# Altium schematic sheet keen3_filet.SchDoc
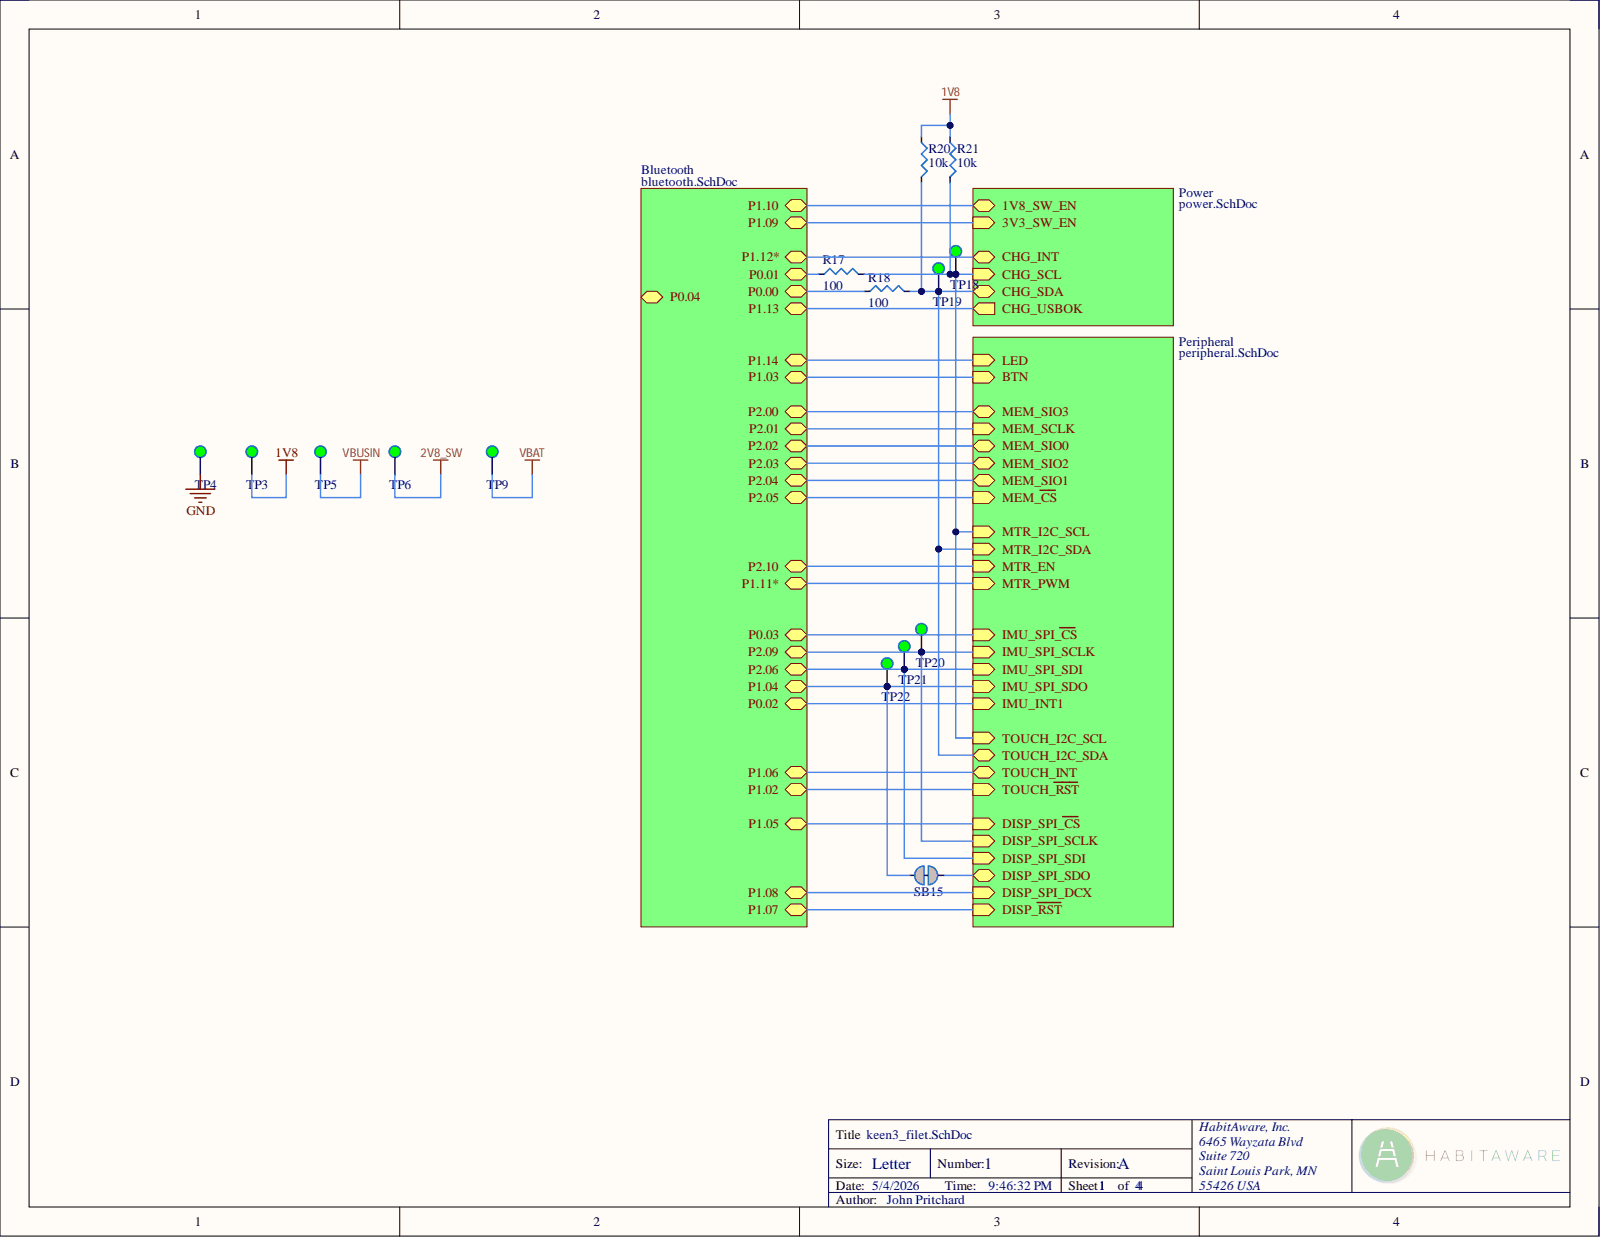
[diagram p0: keen3_filet.SchDoc]

## Parts
R17  100  [RES0603]
R18  100  [RES0603]
R20  10k  [RES0603]
R21  10k  [RES0603]
SB15  Solderbridge  [Solderbridge_Shorted]  (value not drawn)
TP3  1V8  [TP_TH]
TP4  GND  [TP_TH]
TP5  VBUSIN  [TP_TH]
TP6  2V8_SW  [TP_TH]
TP9  VBAT  [TP_TH]
TP18  TP_SMT  [TP_SMT_1.5MM]  (value not drawn)
TP19  TP_SMT  [TP_SMT_1.5MM]  (value not drawn)
TP20  TP_SMT  [TP_SMT_1.5MM]  (value not drawn)
TP21  TP_SMT  [TP_SMT_1.5MM]  (value not drawn)
TP22  TP_SMT  [TP_SMT_1.5MM]  (value not drawn)

## Nets
1V8: R20.1 R21.1 TP3.1
2V8_SW: TP6.1
GND: TP4.1
VBAT: TP9.1
VBUSIN: TP5.1
NetR17_1: R17.1
NetR17_2: R17.2 R21.2 TP18.1
NetR18_1: R18.1
NetR18_2: R18.2 R20.2 TP19.1
NetSB15_1: SB15.1 TP22.1
NetSB15_2: SB15.2
NetTP20_1: TP20.1
NetTP21_1: TP21.1
1V8_SW_EN:
3V3_SW_EN:
BTN:
CHG_INT:
CHG_USBOK:
DISP_~{RST}:
DISP_SPI_~{CS}:
DISP_SPI_DCX:
IMU_INT1:
IMU_SPI_~{CS}:
LED:
MEM_~{CS}:
MEM_SCLK:
MEM_SIO0:
MEM_SIO1:
MEM_SIO2:
MEM_SIO3:
MTR_EN:
MTR_PWM:
P0.04:
P1.02:
P1.06:

## Library data of the parts
R17 R18 = RES: Chip Resistor; Manufacturer: Cal-Chip Electronics, Inc.; Part Number: RM06F1000CT; Note: RES SMD 100 OHM 1% 1/10W 0603; Specification: 100 Ohms ±1% 0.1W, 1/10W Chip Resistor 0603 (1608 Metric) Thick Film; Component Kind: Standard; Value: 100; Library Name: ware.IntLib
R20 R21 = RES: Chip Resistor; Manufacturer: Samsung Electro-Mechanics; Part Number: RCS1608F103CS; Note: RES 10K OHM 1% 1/10W 0603; Specification: 10 kOhms ±1% 0.1W, 1/10W Chip Resistor 0603 (1608 Metric) Anti-Sulfur, Moisture Resistant Thick Film; Component Kind: Standard; Value: 10k; Library Name: ware.IntLib
SB15 = SOLDERBRIDGE_SHORTED: Shorted Solderbridge; Part Number: Solderbridge Shorted; Component Kind: Net Tie; Value: Solderbridge; Library Name: ware.IntLib
TP3-TP6 TP9 = Test Point: Value: TP_SMT; Component Kind: Standard; Description: Test point; Library Name: ware.IntLib
TP18-TP22 = Test Point: Component Kind: Standard; Description: Test point; Value: TP_SMT; Library Name: ware.IntLib
